# Altium schematic sheet Top Level.SchDoc("Top Level")
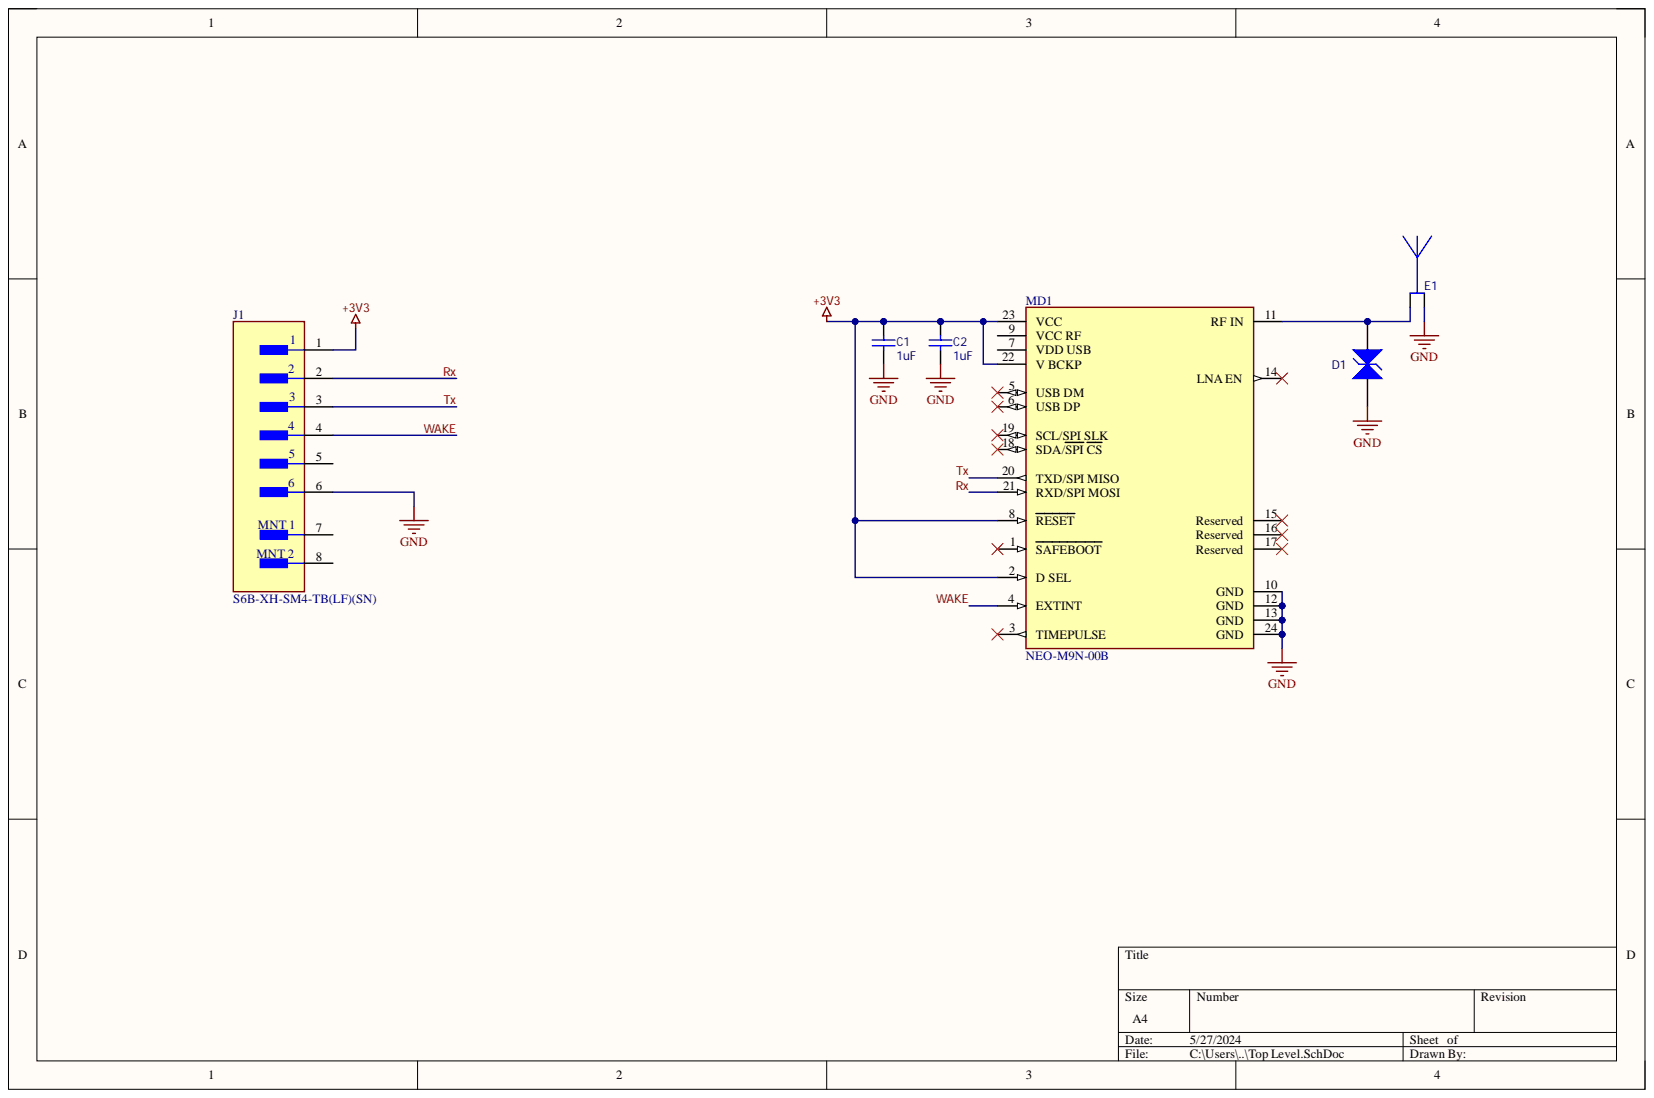
[diagram p0: Top Level.SchDoc]

## Parts
C1  value 1uF  Capacitance: 1uF  [CAPC1608X10N]  (hidden comment: C0603C105K8PACTU)
C2  value 1uF  Capacitance: 1uF  [CAPC1608X10N]  (hidden comment: C0603C105K8PACTU)
D1  CGA0402MLC-05G  [DIOC1005X04N]  (value not drawn)
E1  SGGP.25.4.A.02  [SGGP.25.4.A.02-Footprint-1]  (value not drawn)
J1  S6B-XH-SM4-TB(LF)(SN)  [FP-S6B-XH-SM4-TB_LF_SN-MFG]
MD1  NEO-M9N-00B  [FP-NEO-M9N-00B-MFG]

## Nets
+3V3: C1.2 C2.2 J1.1 MD1.2(D_SEL) MD1.8(RESET) MD1.22(V_BCKP) MD1.23(VCC)
GND: C1.1 C2.1 D1.1 E1.2 J1.6 MD1.10(GND) MD1.12(GND) MD1.13(GND) MD1.24(GND)
Rx: J1.2 MD1.21(RXD/SPI_MOSI)
Tx: J1.3 MD1.20(TXD/SPI_MISO)
WAKE: J1.4 MD1.4(EXTINT)
NetD1_2: D1.2 E1.1 MD1.11(RF_IN)

Not connected: J1 5 7 8; MD1 1(SAFEBOOT) 3(TIMEPULSE) 5(USB_DM) 6(USB_DP) 7(VDD_USB) 9(VCC_RF) 14(LNA_EN) 15(Reserved) 16(Reserved) 17(Reserved) 18(SDA/SPI_CS) 19(SCL/SPI_SLK)

## Library data of the parts
C1 C2 = CMP-00045-1: Cap Ceramic 1uF 10V X5R 10% SMD 0603 85°C Paper T/R; Case Code (Imperial): 0603; Case Code (Metric): 1608; Case/Package: 0603; Component Kind: Standard; Depth: 0.8mm; Dielectric: X5R; Dielectric Material: Ceramic; Height: 0.8mm; Lead Free: Lead Free; Length: 1.6mm; Max Operating Temperature: 85°C; Min Operating Temperature: -55°C; Mount: Surface Mount; Number of Pins: 2; Package Quantity: 1; Packaging: Tape and Reel; Radiation Hardening: No; REACH SVHC: No SVHC; Termination: SMD/SMT; Thickness: 0.035inch; Tolerance: 10%; Voltage: 10V; Voltage Rating: 10V; Voltage Rating (DC): 10V; Weight: 7.1E-05oz; Width: 0.031inch; Library Name: UWA Aerospace
D1 = CMP-00164-1: ESD Suppressor TVS 5V Automotive 2-Pin Case 0402 T/R; Capacitance: 0.2pF; Clamping Voltage: 30V; Current Rating: 5nA; Direction: Bidirectional; Element Configuration: Single; ESD Protection: Yes; Leakage Current: 10nA; Number of Circuits: 1; Case Code (Imperial): 0402; Case Code (Metric): 1005; Case/Package: 0402; Component Kind: Standard; Contact Plating: Tin; Depth: 0.5mm; Height: 0.34mm; Length: 1mm; Max Operating Temperature: 125°C; Max Reverse Leakage Current: 5nA; Max Voltage Rating (DC): 5V; Min Operating Temperature: -55°C; Mount: Surface Mount; Number of Pins: 2; Operating Supply Voltage: 5V; Package Quantity: 10000; Packaging: Tape and Reel; Radiation Hardening: No; REACH SVHC: No SVHC; Termination: SMD/SMT; Voltage Rating (DC): 5V; Width: 0.5mm; Working Voltage: 5V; Library Name: UWA Aerospace
E1 = CMP-00032-2: ANTENNA GPS CERAMIC PATCH SMD; Impedance: 50R; Max Frequency: 1.607GHz; Number of Bands: 2; Case/Package: SMD/SMT; Component Kind: Standard; Height: 0.177inch; Mount: Surface Mount; Package Quantity: 200; Termination: Solder; Library Name: UWA Aerospace
J1 = CMP-17439-000164-1: CONN HEADER SMD R/A 6POS 2.5MM; Contact Resistance: 20mR; Gender: Male; Max Current Rating: 3A; Max Voltage Rating (AC): 250V; Number of Contacts: 6; Color: Natural; Component Kind: Standard; Connector Type: Header; Contact Finish - Mating: TIN; Contact Material: Copper Alloy; Contact Plating: Copper; Contact Shape: Square; Contact Type: Male Pin; Depth: 7mm; Fastening Type: Detent; Flammability Rating: UL94 V-0; Housing Color: Beige; Housing Material: Polyamide; Insulation Color: Natural; Insulation Material: Nylon; Lead Free: Lead Free; Length: 20mm; Library Name: Altium Content Vault; Max Operating Temperature: 85°C; Min Operating Temperature: -25°C; Mount: Surface Mount; Number of Positions: 6; Number of Rows: 1; Orientation: Right Angle; Package Quantity: 1; Packaging: Tape and Reel; Pitch: 2.5mm; REACH SVHC: No SVHC; Series: XH; Termination: Solder; Voltage Rating: 250V
MD1 = CMP-207130-000006-2: M9 standard precision module; Manufacturer: u-blox; Interface: I2C,SPI,UART,USB; Max Supply Voltage: 3.6V; Min Supply Voltage: 1.65V; Sensitivity: -167dBm; Automotive: No; Category: UNK; Component Kind: Standard; ComponentLink2Description: Datasheet; ComponentLink2URL: https://www.u-blox.com/sites/default/files/NEO-M9N_DataSheet_%28UBX-19014285%29.pdf; Device Class L1: Integrated Circuits (ICs); Device Class L2: RF Semiconductors and Devices; Device Class L3: RF Receivers; Footprint Url: https://www.u-blox.com/sites/default/files/NEO-M9N_Integrationmanual_%28UBX-19014286%29.pdf; Height: 2.6mm; Lead Free: Yes; Library Name: Altium Content Vault; Manufacturer Part Number: NEO-M9N-00B; Octopart: https://octopart.com/neo-m9n-00b-u-blox-107315722; Package: 0; RoHS: TRUE; Temperature: -40°C to +85°C; Temperature Range High: +85°C; Temperature Range Low: -40°C
